# Altium schematic sheet DigitalLabBoard_Die.SchDoc(DigitalLabBoard_Die)
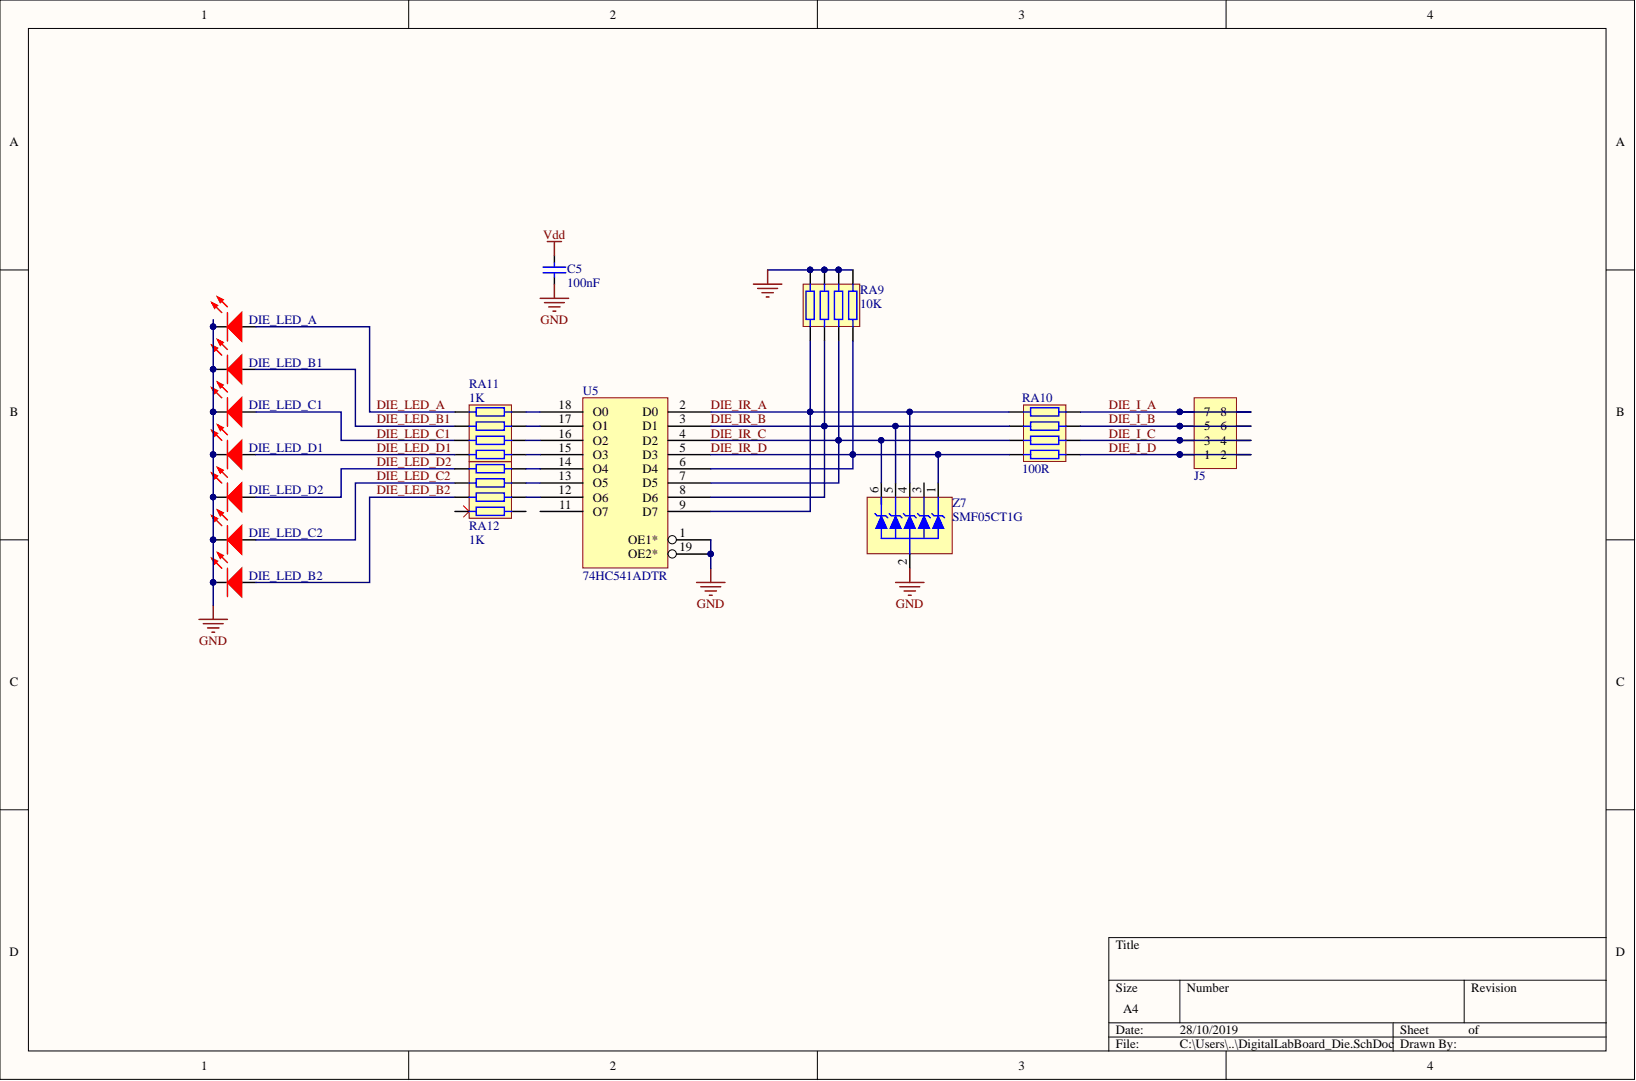
[diagram p0: DigitalLabBoard_Die.SchDoc]

## Parts
C5  100nF  [CCNP2012-0805]
DIE_LED_A  LED Red  [LED1608-0603-RED]  (value not drawn)
DIE_LED_B1  LED Red  [LED1608-0603-RED]  (value not drawn)
DIE_LED_B2  LED Red  [LED1608-0603-RED]  (value not drawn)
DIE_LED_C1  LED Red  [LED1608-0603-RED]  (value not drawn)
DIE_LED_C2  LED Red  [LED1608-0603-RED]  (value not drawn)
DIE_LED_D1  LED Red  [LED1608-0603-RED]  (value not drawn)
DIE_LED_D2  LED Red  [LED1608-0603-RED]  (value not drawn)
J5  2x5 Header  [HDR2X4S]  (value not drawn)
RA9  10K  [CR1206-0603_X4]
RA10  100R  [CR1206-0603_X4]
RA11  1K  [CR1206-0603_X4]
RA12  1K  [CR1206-0603_X4]
U5  74HC541ADTR  [TSSOP20]
Z7  SMF05CT1G  [SC70_N]

## Nets
GND: C5.1 DIE_LED_A.K DIE_LED_B1.K DIE_LED_B2.K DIE_LED_C1.K DIE_LED_C2.K DIE_LED_D1.K DIE_LED_D2.K RA9.5 RA9.6 RA9.7 RA9.8 U5.1(OE1*) U5.10 U5.19(OE2*) Z7.2
Vcc: U5.20
Vdd: C5.2
DIE_I_A: J5.7 J5.8 RA10.8
DIE_I_B: J5.5 J5.6 RA10.7
DIE_I_C: J5.3 J5.4 RA10.6
DIE_I_D: J5.1 J5.2 RA10.5
DIE_IR_A: RA9.4 RA10.1 U5.2(D0) U5.9(D7) Z7.4
DIE_IR_B: RA9.3 RA10.2 U5.3(D1) U5.8(D6) Z7.5
DIE_IR_C: RA9.2 RA10.3 U5.4(D2) U5.7(D5) Z7.6
DIE_IR_D: RA9.1 RA10.4 U5.5(D3) U5.6(D4) Z7.1
DIE_LED_A: DIE_LED_A.A RA11.8
DIE_LED_B1: DIE_LED_B1.A RA11.7
DIE_LED_B2: DIE_LED_B2.A RA12.6
DIE_LED_C1: DIE_LED_C1.A RA11.6
DIE_LED_C2: DIE_LED_C2.A RA12.7
DIE_LED_D1: DIE_LED_D1.A RA11.5
DIE_LED_D2: DIE_LED_D2.A RA12.8
NetRA11_1: RA11.1 U5.18(O0)
NetRA11_2: RA11.2 U5.17(O1)
NetRA11_3: RA11.3 U5.16(O2)
NetRA11_4: RA11.4 U5.15(O3)
NetRA12_1: RA12.1 U5.14(O4)
NetRA12_2: RA12.2 U5.13(O5)
NetRA12_3: RA12.3 U5.12(O6)

Not connected: RA12 4 5; U5 11(O7); Z7 3

## Library data of the parts
C5 = Cap_CCNP_0805: Capacitor non-polar SMT 0805; Manufacturer: Generic Components; Class: Passive; Value: 100nF; SubClass: Capacitor; Simulation: CAP; Signal Integrity: Cap; Published: 08/06/2000; Library Name: MyLibrary.IntLib; Component Kind: Standard; Publisher: Altium Hobart Technology Centre
DIE_LED_A DIE_LED_B1 DIE_LED_B2 DIE_LED_C1 DIE_LED_C2 DIE_LED_D1 DIE_LED_D2 = LED-0603-Red: LED 0603 Red; Manufacturer: Generic Components; Class I: Optoelectronic; Revision: July-2002: Re-released for DXP Platform.; Published: 8-Jun-2000; Library Name: MySchLib.schlib; Farnell PN: 1581239; Component Kind: Standard; Class II: LED; Publisher: Altium Limited
J5 = Header 2x4a: Header 2x4; Library Name: MyLibrary.IntLib; Component Kind: Standard
RA9-RA12 = Res_CR0603x4: SMT x4 Resistor Array; Library Name: MyLibrary.IntLib; Component Kind: Standard
  RA9: Value: 10K
  RA10: Value: 100R
  RA11 RA12: Value: 1K
U5 = 74HC541ADTR: Octal 3-State Buffer/Line Driver/LineReceiver; Library Name: MyLibrary.IntLib; Component Kind: Standard
Z7 = SMF05CT1G: TVS DIODE ARRAY, 100W, 5V, SC-70; Library Name: MySchLib.schlib; Farnell PN: 2533147; Component Kind: Standard
